# Altium schematic sheet SimpleNYT.SchDoc(SimpleNYT)
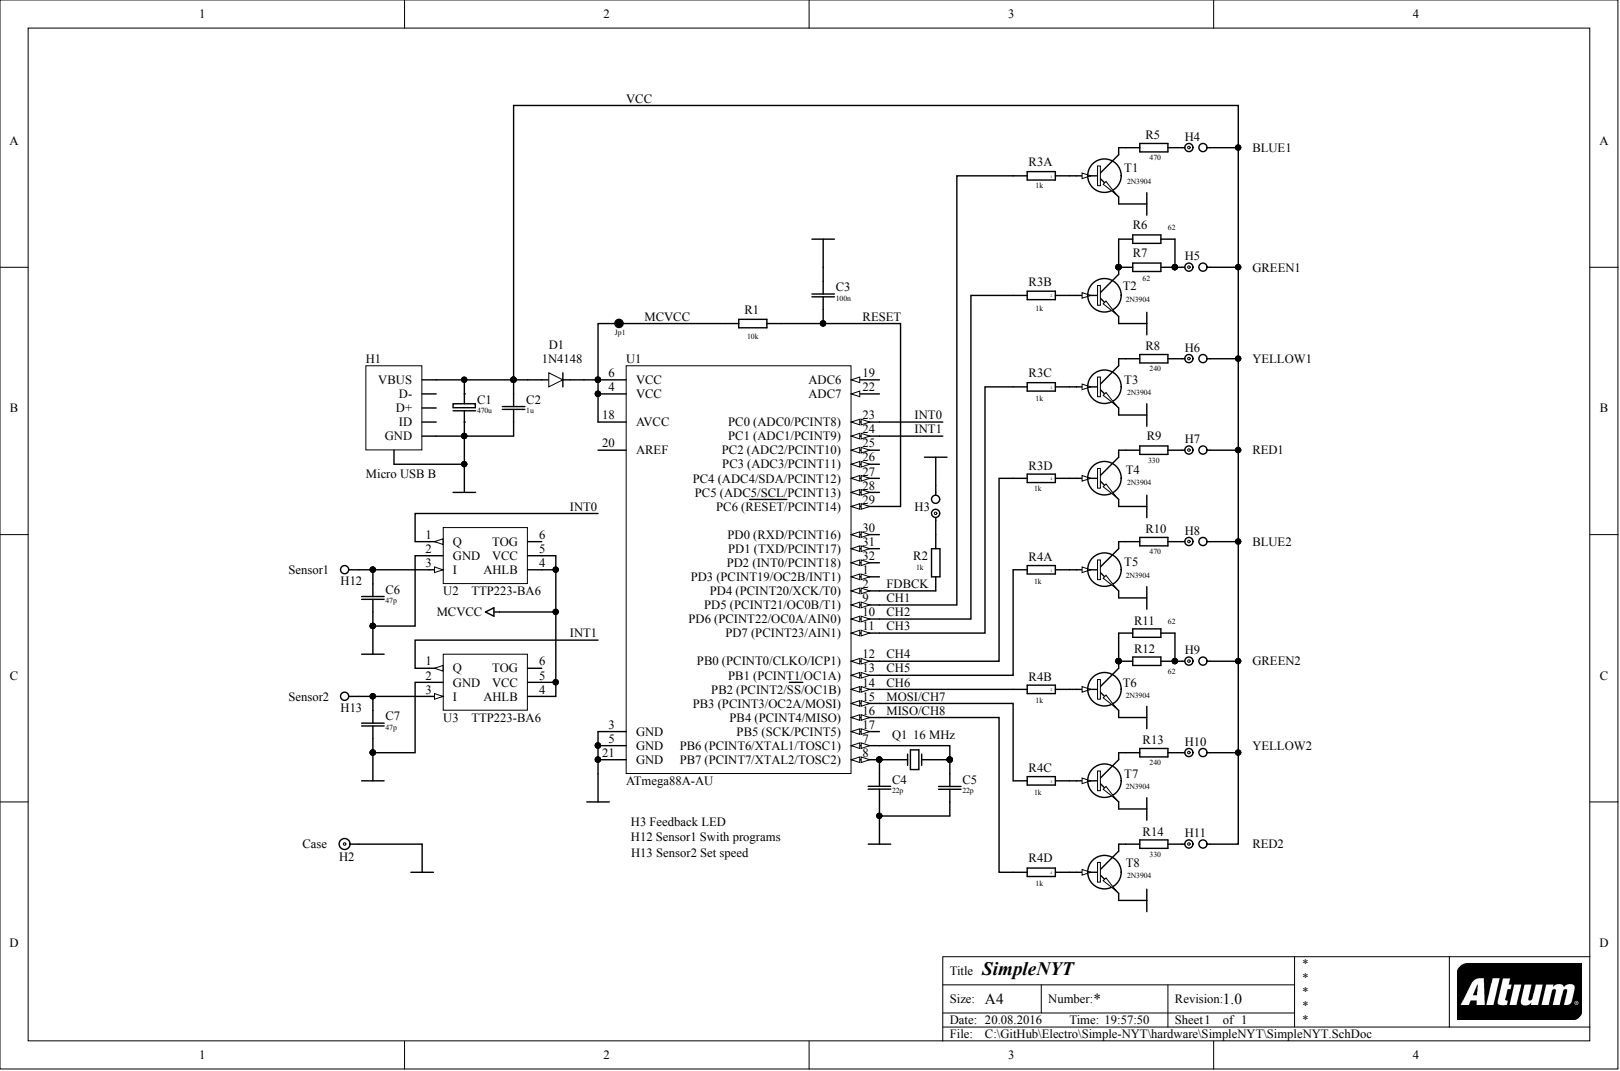
[diagram p0: SimpleNYT.SchDoc]

## Parts
C1  470u  [El-d8-h12-F3.81]
C2  1u  [C0805(2013)]
C3  100n  [C0805(2013)]
C4  22p  [C0805(2013)]
C5  22p  [C0805(2013)]
C6  47p  [C0805(2013)]
C7  47p  [C0805(2013)]
D1  1N4148  [SOD323]
H1  Micro USB B  [DX4R005H91]
H2  HR-1x1  [HR-1x1]  (value not drawn)
H3  H-1x2  [J1x2]  (value not drawn)
H4  H-1x2  [J1x2]  (value not drawn)
H5  H-1x2  [J1x2]  (value not drawn)
H6  H-1x2  [J1x2]  (value not drawn)
H7  H-1x2  [J1x2]  (value not drawn)
H8  H-1x2  [J1x2]  (value not drawn)
H9  H-1x2  [J1x2]  (value not drawn)
H10  H-1x2  [J1x2]  (value not drawn)
H11  H-1x2  [J1x2]  (value not drawn)
H12  H-1  [J1]
H13  H-1  [J1]
Jp1  0  [J-1206]  (value not drawn)
Q1  16 MHz  [HC49/4H_SMX]
R1  10k  [R0805]
R2  1k  [R0805]
R3  1k  [RM4x0603]  (parts A,B,C,D)
R4  1k  [RM4x0603]  (parts A,B,C,D)
R5  470  [R1206]
R6  62  [R1206]
R7  62  [R1206]
R8  240  [R1206]
R9  330  [R1206]
R10  470  [R1206]
R11  62  [R1206]
R12  62  [R1206]
R13  240  [R1206]
R14  330  [R1206]
T1  2N3904  [SOT23]
T2  2N3904  [SOT23]
T3  2N3904  [SOT23]
T4  2N3904  [SOT23]
T5  2N3904  [SOT23]
T6  2N3904  [SOT23]
T7  2N3904  [SOT23]
T8  2N3904  [SOT23]
U1  ATmega88A-AU  [32A_N]
U2  TTP223-BA6  [SOT23-6]
U3  TTP223-BA6  [SOT23-6]

## Nets
GND: C1.2 C2.2 C3.1 C4.2 C5.2 C6.2 C7.2 H1.5(GND) H1.6 H2.1 H3.2 T1.2 T2.2 T3.2 T4.2 T5.2 T6.2 T7.2 T8.2 U1.3(GND) U1.5(GND) U1.21(GND) U2.2(GND) U3.2(GND)
CH1: R3.8 U1.9(PD5_(PCINT21/OC0B/T1))
CH2: R3.7 U1.10(PD6_(PCINT22/OC0A/AIN0))
CH3: R3.6 U1.11(PD7_(PCINT23/AIN1))
CH4: R3.5 U1.12(PB0_(PCINT0/CLKO/ICP1))
CH5: R4.8 U1.13(PB1_(PCINT1/OC1A))
CH6: R4.7 U1.14(PB2_(PCINT2/SS/OC1B))
FDBCK: R2.2 U1.2(PD4_(PCINT20/XCK/T0))
INT0: U1.23(PC0_(ADC0/PCINT8)) U2.1(Q)
INT1: U1.24(PC1_(ADC1/PCINT9)) U3.1(Q)
MCVCC: D1.2 Jp1.1 Jp1.2 R1.2 U1.4(VCC) U1.6(VCC) U1.18(AVCC) U2.4(AHLB) U2.5(VCC) U3.4(AHLB) U3.5(VCC)
MISO/CH8: R4.5 U1.16(PB4_(PCINT4/MISO))
MOSI/CH7: R4.6 U1.15(PB3_(PCINT3/OC2A/MOSI))
RESET: C3.2 R1.1 U1.29(PC6_(RESET/PCINT14))
VCC: C1.1 C2.1 D1.1 H1.1(VBUS) H4.2 H5.2 H6.2 H7.2 H8.2 H9.2 H10.2 H11.2
NetC4_1: C4.1 Q1.1 U1.8
NetC5_1: C5.1 Q1.2 U1.7
NetC6_1: C6.1 H12.1 U2.3(I)
NetC7_1: C7.1 H13.1 U3.3(I)
NetH3_1: H3.1 R2.1
NetH4_1: H4.1 R5.1
NetH5_1: H5.1 R6.1 R7.1
NetH6_1: H6.1 R8.1
NetH7_1: H7.1 R9.1
NetH8_1: H8.1 R10.1
NetH9_1: H9.1 R11.1 R12.1
NetH10_1: H10.1 R13.1
NetH11_1: H11.1 R14.1
NetR3_1: R3.1 T1.1
NetR3_2: R3.2 T2.1
NetR3_3: R3.3 T3.1
NetR3_4: R3.4 T4.1
NetR4_1: R4.1 T5.1
NetR4_2: R4.2 T6.1
NetR4_3: R4.3 T7.1
NetR4_4: R4.4 T8.1
NetR5_2: R5.2 T1.3
NetR6_2: R6.2 R7.2 T2.3
NetR8_2: R8.2 T3.3
NetR9_2: R9.2 T4.3
NetR10_2: R10.2 T5.3
NetR11_2: R11.2 R12.2 T6.3
NetR13_2: R13.2 T7.3
NetR14_2: R14.2 T8.3

Not connected: H1 2(D-) 3(D+) 4(ID); U1 1(PD3_(PCINT19/OC2B/INT1)) 17(PB5_(SCK/PCINT5)) 19(ADC6) 20(AREF) 22(ADC7) 25 26(PC3_(ADC3/PCINT11)) 27(PC4_(ADC4/SDA/PCINT12)) 28(PC5_(ADC5/SCL/PCINT13)) 30(PD0_(RXD/PCINT16)) 31(PD1_(TXD/PCINT17)) 32(PD2_(INT0/PCINT18)); U2 6(TOG); U3 6(TOG)

## Library data of the parts
C1 = 470u-16V: 16V; Component Kind: Standard; Library Name: Cap-El.IntLib; Price: 0.1; Signal Integrity: C; Simulation: C; Value: 470u
C2-C7 = C-0805: SMD-0805; Component Kind: Standard; Library Name: SMD-RCL.IntLib; Price: 0.014; Signal Integrity: Cap; Simulation: Capacitor
  C2: Value: 1u
  C3: Value: 100n
  C4 C5: Value: 22p
  C6 C7: Value: 47p
D1 = 1N4148: DO-35 75V 0.2A 1uS 4A 4nS; Component Kind: Standard; Library Name: Diodes.IntLib; Price: 0.02; Signal Integrity: D; Simulation: 1N4148
H1 = Micro USB B: DX4R005H91; Component Kind: Standard; Library Name: nyt_lib.SchLib
Jp1 = Jp-1206: SMD-1206-om; Component Kind: Standard; Library Name: SMD-RCL.IntLib; Price: 0.045
Q1 = HC49/4H_SMX: Surface Mount Quartz Crystal; Note: PCB layout complies with manufacturer's recommendation.; Component Kind: Standard; ComponentLink1Description: Manufacturer Link; ComponentLink1URL: http://www.cmac.com/mt/; ComponentLink2Description: Datasheet; ComponentLink2URL: http://www.cmac.com/mt/databook/crystals/smd/hc49_4h_smx.pdf; DatasheetDocument: 18-Oct-1999; Freq: 16 MHz; Library Name: C-MAC Crystal Oscillator.IntLib; PackageDocument: 18-Oct-1999; PackageReference: HC49/4H_SMX; Published: 17-Jul-2002; Publisher: Altium Limited
R1 R2 = R-0805: SMD 0805 0.1W 5% 150V; Component Kind: Standard; Library Name: SMD-RCL.IntLib; Price: 0.017; Signal Integrity: Res; Simulation: Resistor
  R1: Value: 10k
  R2: Value: 1k
R3 R4 = 4RM-0603: SMD 4RM-0603 1\16W 5%; Component Kind: Standard; Library Name: SMD-RCL.IntLib; Price: 0.07; Signal Integrity: Res; Simulation: RESISTOR; Value: 1k
R5-R14 = R-1206: SMD 1206 0.125W 5% 200V; Component Kind: Standard; Library Name: SMD-RCL.IntLib; Price: 0.045; Signal Integrity: Res; Simulation: Resistor
  R5 R10: Value: 470
  R6 R7 R11 R12: Value: 62
  R8 R13: Value: 240
  R9 R14: Value: 330
T1-T8 = BC847C: SMD-SOT23; Component Kind: Standard; Library Name: BJT.IntLib; Price: 0.04; Signal Integrity: Q; Simulation: BC847C
U1 = ATmega88A-AU: 8-bit AVR Microcontroller, 8KB Flash, 512 Bytes EEPROM, 1KB SRAM, 32-pin TQFP, Industrial Grade (-40°C to 85°C); Code_JEDEC: MS-026-ABA; Component Kind: Standard; ComponentLink1Description: Manufacturer URL; ComponentLink1URL: http://www.atmel.com/; ComponentLink2Description: ATmega48A/48PA/88A/88PA/168A/168PA/328/328P Datasheet; ComponentLink2URL: http://www.atmel.com/dyn/resources/prod_documents/doc8271.pdf; ComponentLink3Description: ATmega48A/48PA/88A/88PA/168A/168PA/328/328P Summary; ComponentLink3URL: http://www.atmel.com/dyn/resources/prod_documents/8271S.pdf; DatasheetVersion: revD, May-2011; Library Name: Atmel Microcontroller megaAVR.IntLib; PackageDescription: 32-Lead, 7x7x1.0 mm Body, 0.8mm Lead Pitch, Thin Profile Plastic Quad Flat Package (TQFP); PackageReference: 32A; PackageVersion: revC, Oct-2010
U2 U3 = TTP223-BA6: Touch pad detector IC; Component Kind: Standard; Name: TTP223-BA6; Library Name: nyt_lib.SchLib
H2 = HR-1x1: Component Kind: Standard; Library Name: Connektor.IntLib; Price: 0.01
H3-H11 = H-1x2: Component Kind: Standard; Library Name: Connektor.IntLib; Price: 0.02
H12 H13 = H-1: Component Kind: Standard; Library Name: Connektor.IntLib; Price: 0.01
